# Altium schematic sheet DISPLAY.SchDoc(DISPLAY)
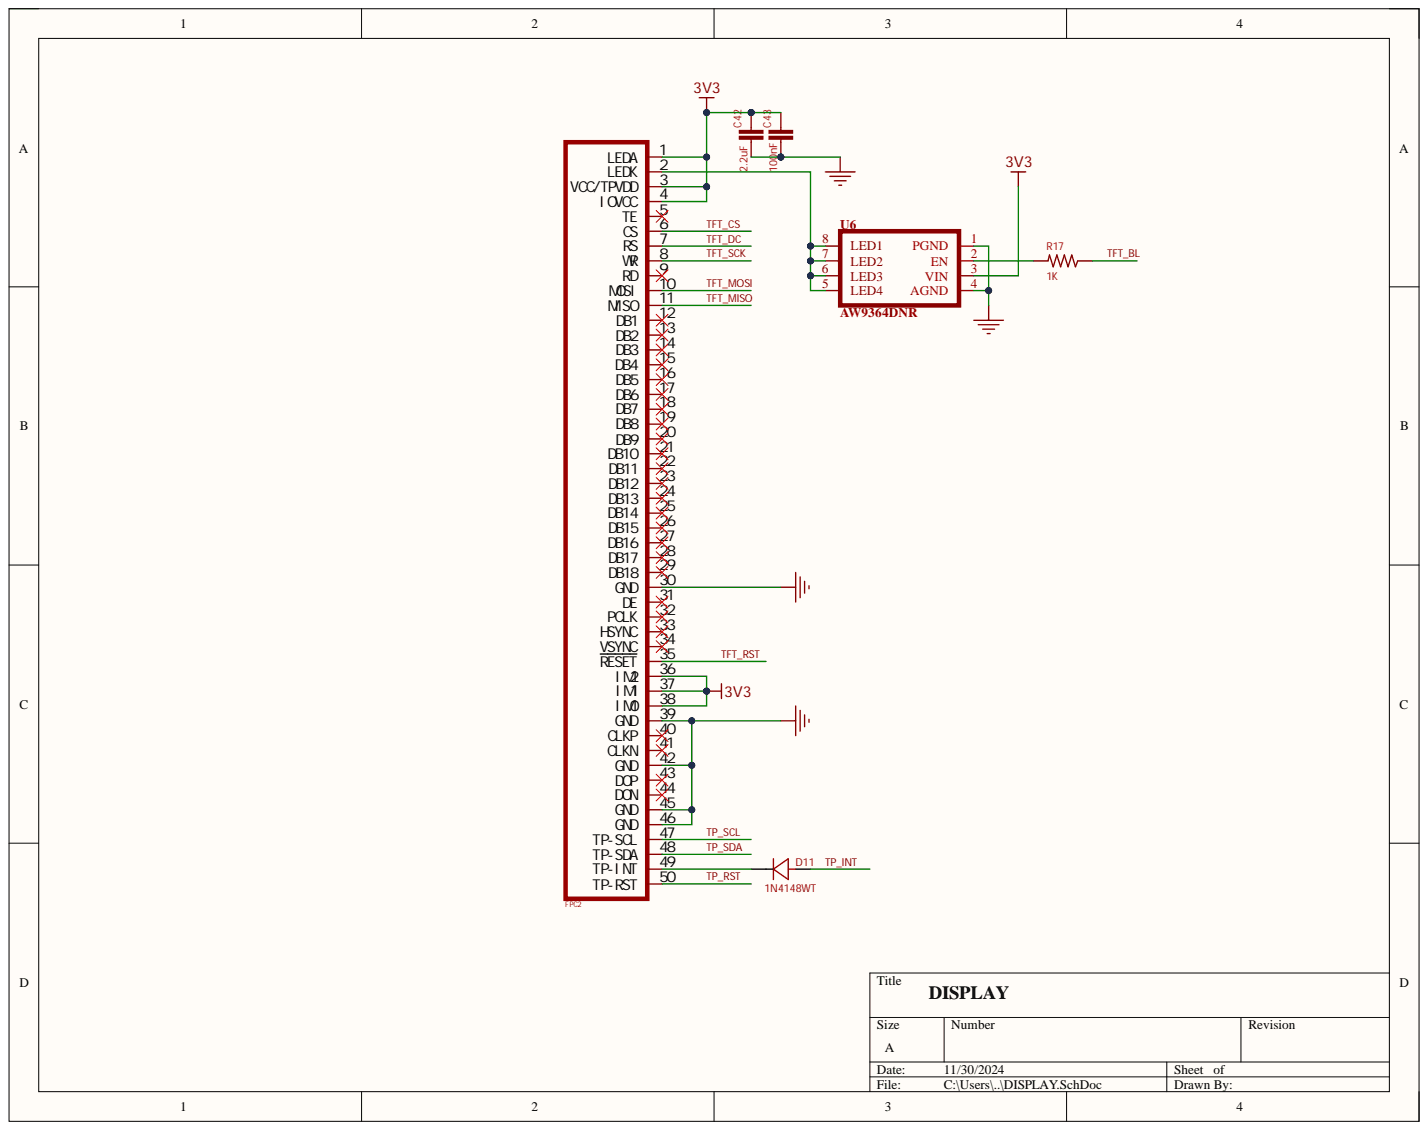
[diagram p0: DISPLAY.SchDoc]

## Parts
C42  2.2uF  [C0402]
C43  100nF  [C0402]
D11  1N4148WT  [SOD-523_L1.2-W0.8-LS1.6-FD]
FPC2  TFT-2.33Inc  [CONN-TH-50P_AFC24-S50FIA-00]  (value not drawn)
R17  1K  [R0402]
U6  AW9364DNR  [DFN-8_L2.0-W2.0-P0.50-BL-EP-1]

## Nets
3V3: C42.2 C43.2 FPC2.1(LEDA) FPC2.3(VCC/TPVDD) FPC2.4(IOVCC) FPC2.36(IM2) FPC2.37(IM1) FPC2.38(IM0) U6.3(VIN)
GND: C42.1 C43.1 FPC2.30(GND) FPC2.39(GND) FPC2.42(GND) FPC2.45(GND) FPC2.46(GND) FPC2.51 FPC2.52 U6.1(PGND) U6.4(AGND)
TFT_BL: R17.1
TFT_CS: FPC2.6(CS)
TFT_DC: FPC2.7(RS)
TFT_MISO: FPC2.11(MISO)
TFT_MOSI: FPC2.10(MOSI)
TFT_RST: FPC2.35(RESET)
TFT_SCK: FPC2.8(WR)
TP_INT: D11.1
TP_RST: FPC2.50(TP-RST)
TP_SCL: FPC2.47(TP-SCL)
TP_SDA: FPC2.48(TP-SDA)
NetD11_2: D11.2 FPC2.49(TP-INT)
NetFPC2_2: FPC2.2(LEDK) U6.5(LED4) U6.6(LED3) U6.7(LED2) U6.8(LED1)
NetR17_2: R17.2 U6.2(EN)

Not connected: FPC2 5(TE) 9(RD) 12(DB1) 13(DB2) 14(DB3) 15(DB4) 16(DB5) 17(DB6) 18(DB7) 19(DB8) 20(DB9) 21(DB10) 22(DB11) 23(DB12) 24(DB13) 25(DB14) 26(DB15) 27(DB16) 28(DB17) 29(DB18) 31(DE) 32(PCLK) 33(HSYNC) 34(VSYNC) 40(CLKP) 41(CLKN) 43(DOP) 44(DON)

## Library data of the parts
C42 C43 = C0201: 0201  贴片电容; Component Kind: Standard; Library Name: 常用.SCHLIB
D11 = 1N4148WT: SOD-523 贴片二极管; 系统型号: 暂无; Component Kind: Standard; Library Name: 常用.SCHLIB; 立创链接: https://item.szlcsc.com/3349416.html
FPC2 = TFT-2.33Inc: 间距:0.5mm;触点数量:50;锁定特性:翻盖式;安装类型:卧贴;触点类型:双侧触点/上下接;; Manufacturer: JS(钜硕电子); Add into BOM: yes; Device: AFC24-S50FIA-00; FFC, FCB Thickness: 0.3mm; Locking Feature: 翻盖式; Number of Contacts: 50; Pitch: 0.5mm; Component Kind: Standard; ComponentLink1Description: 立创链接; ComponentLink1URL: https://item.szlcsc.com/253946.html; Contact Type: 双侧触点/上下接; Convert to PCB: yes; Height Above Board: 2mm; JLCPCB Part Class: Extended Part; LCSC Part Name: FPC 0.5MM 50P 前插后翻 H2.0mm 双面接 镀金; Library Name: RP2040.SCHLIB; link: https://item.szlcsc.com/253946.html?ref=editor&amp;logined=true; Manufacturer Part: AFC24-S50FIA-00; Mounting Type: 卧贴; PCB3D: CONN-TH-50P_AFC24-S50FIA-00; Supplier: LCSC; Supplier Footprint: SMD,P=0.5mm; Supplier Part: C262687; Symbol: AFC24-S50FIA-00
R17 = R0402: 0402 贴片电阻; Component Kind: Standard; Library Name: 常用.SCHLIB
U6 = AW9364DNR: Component Kind: Standard; ComponentLink1Description: 立创链接; ComponentLink1URL: https://item.szlcsc.com/385721.html; Library Name: SOP.SCHLIB
